# Altium schematic sheet Power_Configuration.SchDoc
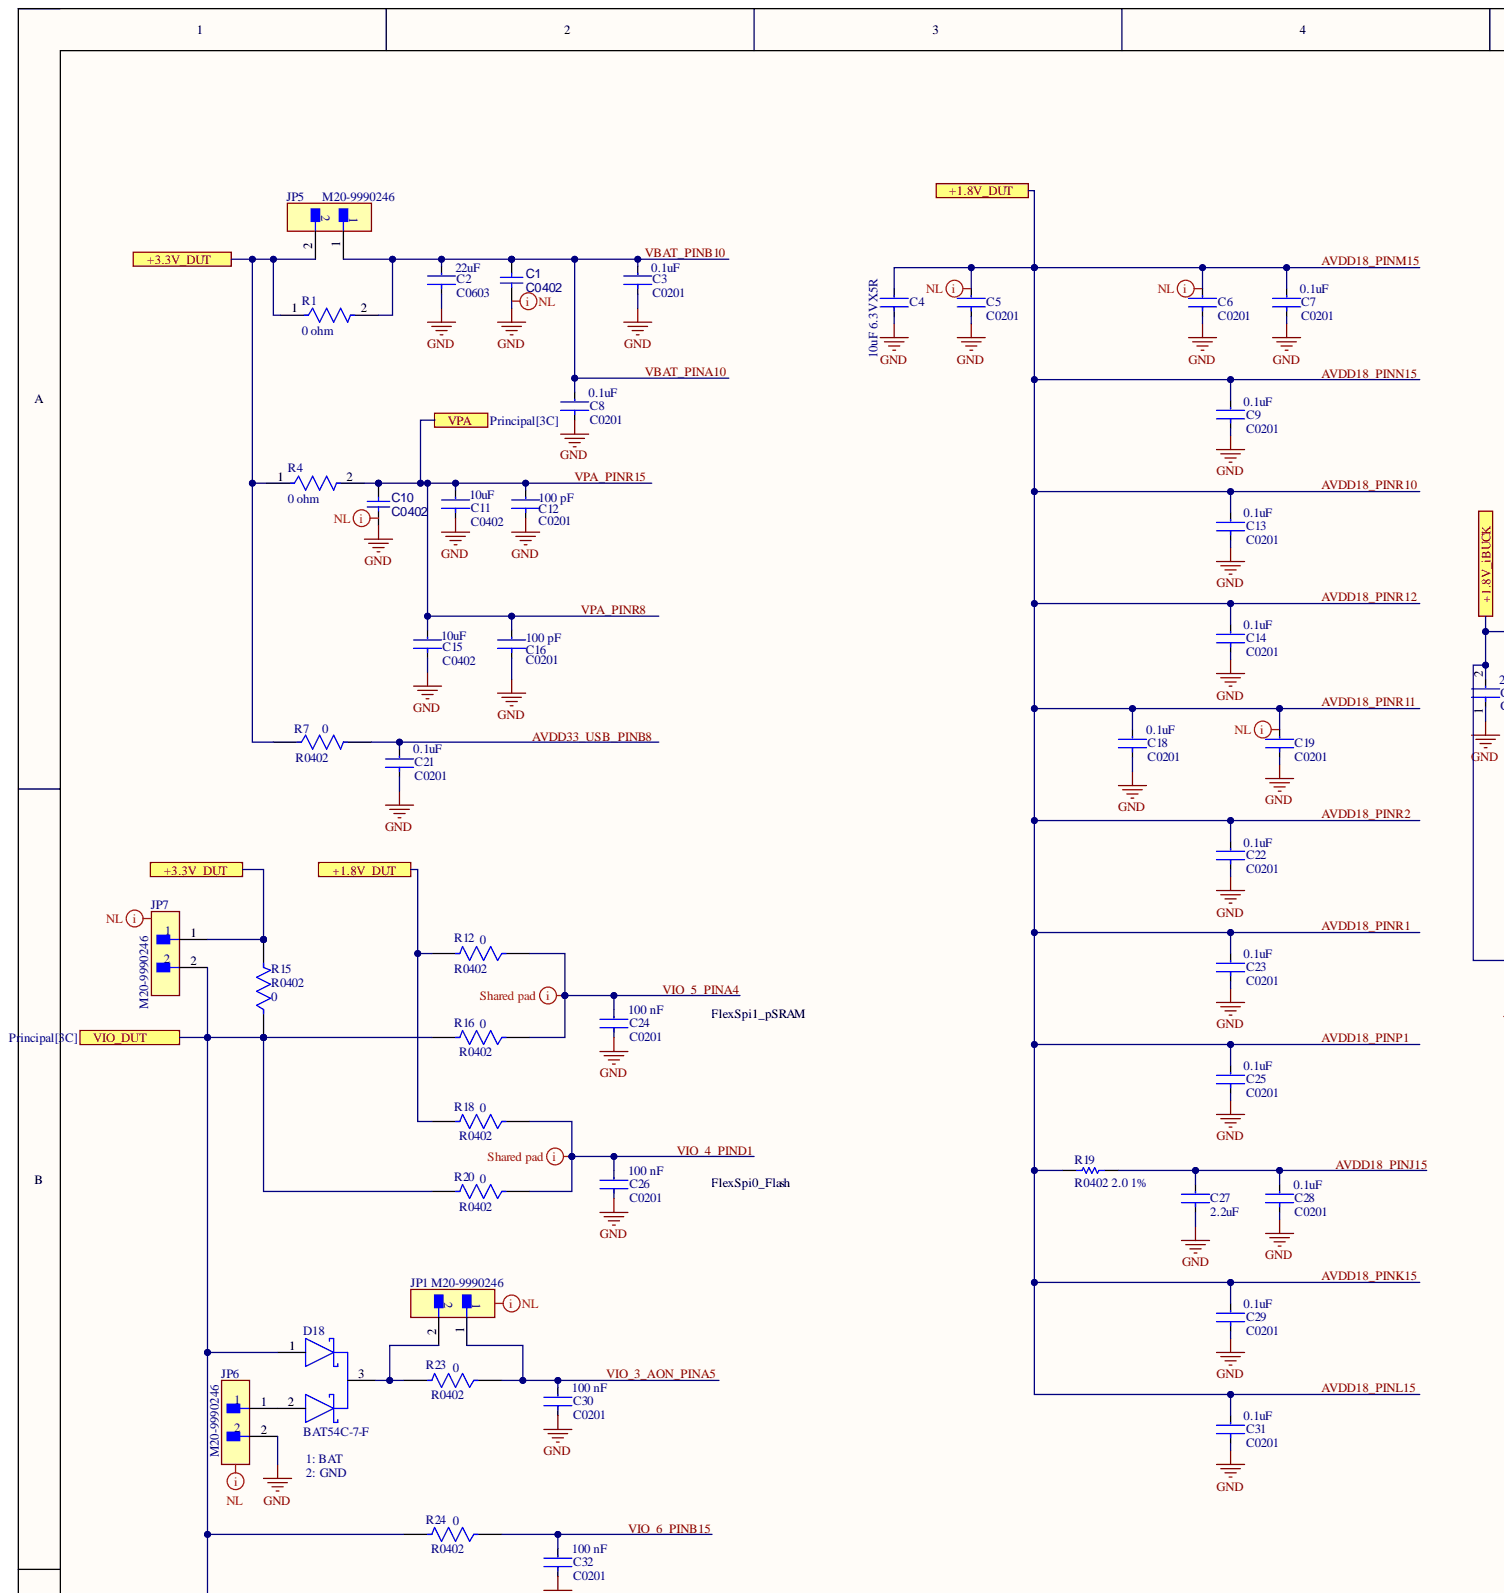
[diagram p0: Power_Configuration.SchDoc, top left]
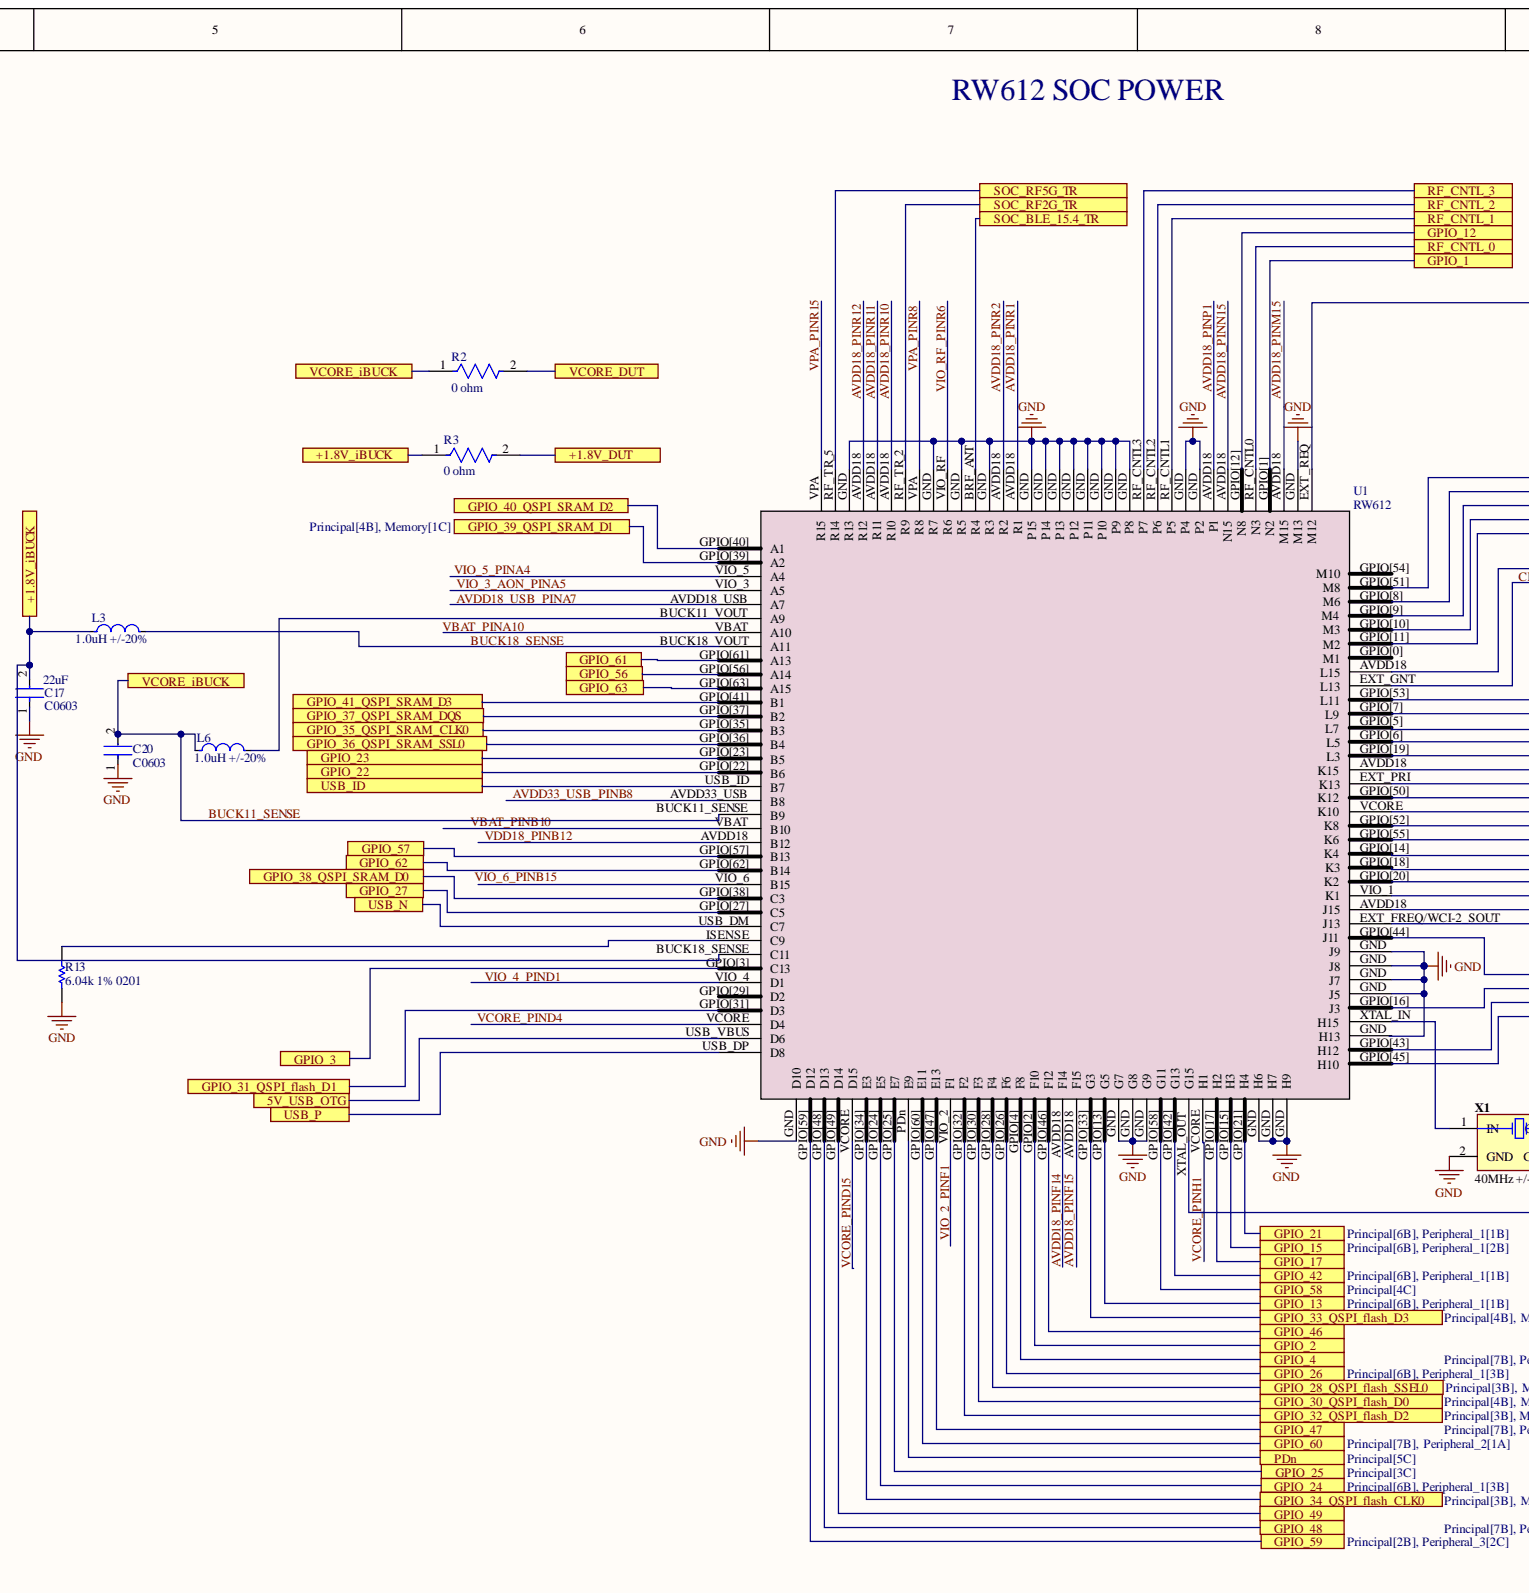
[diagram p1: Power_Configuration.SchDoc, top centre]
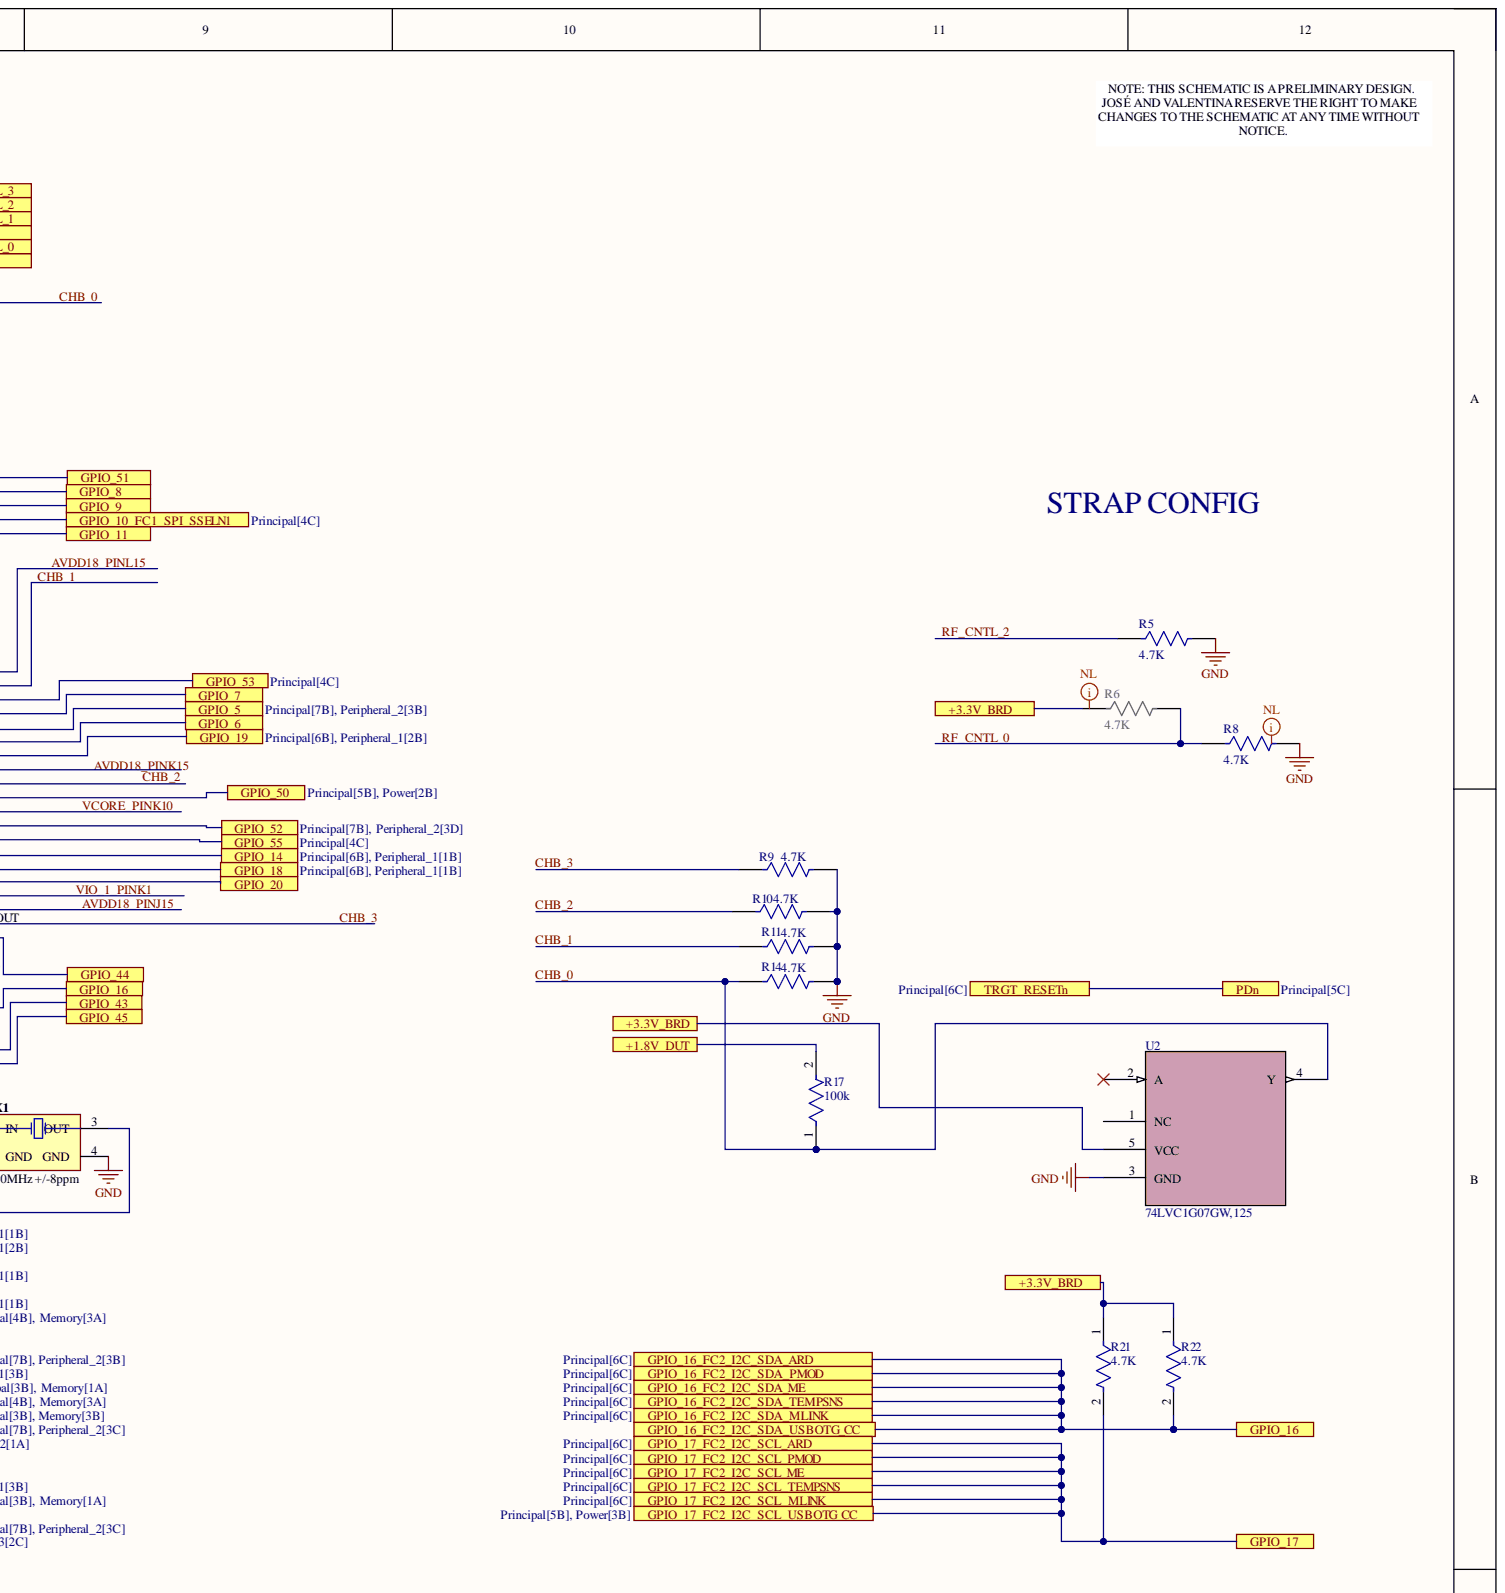
[diagram p2: Power_Configuration.SchDoc, top right]
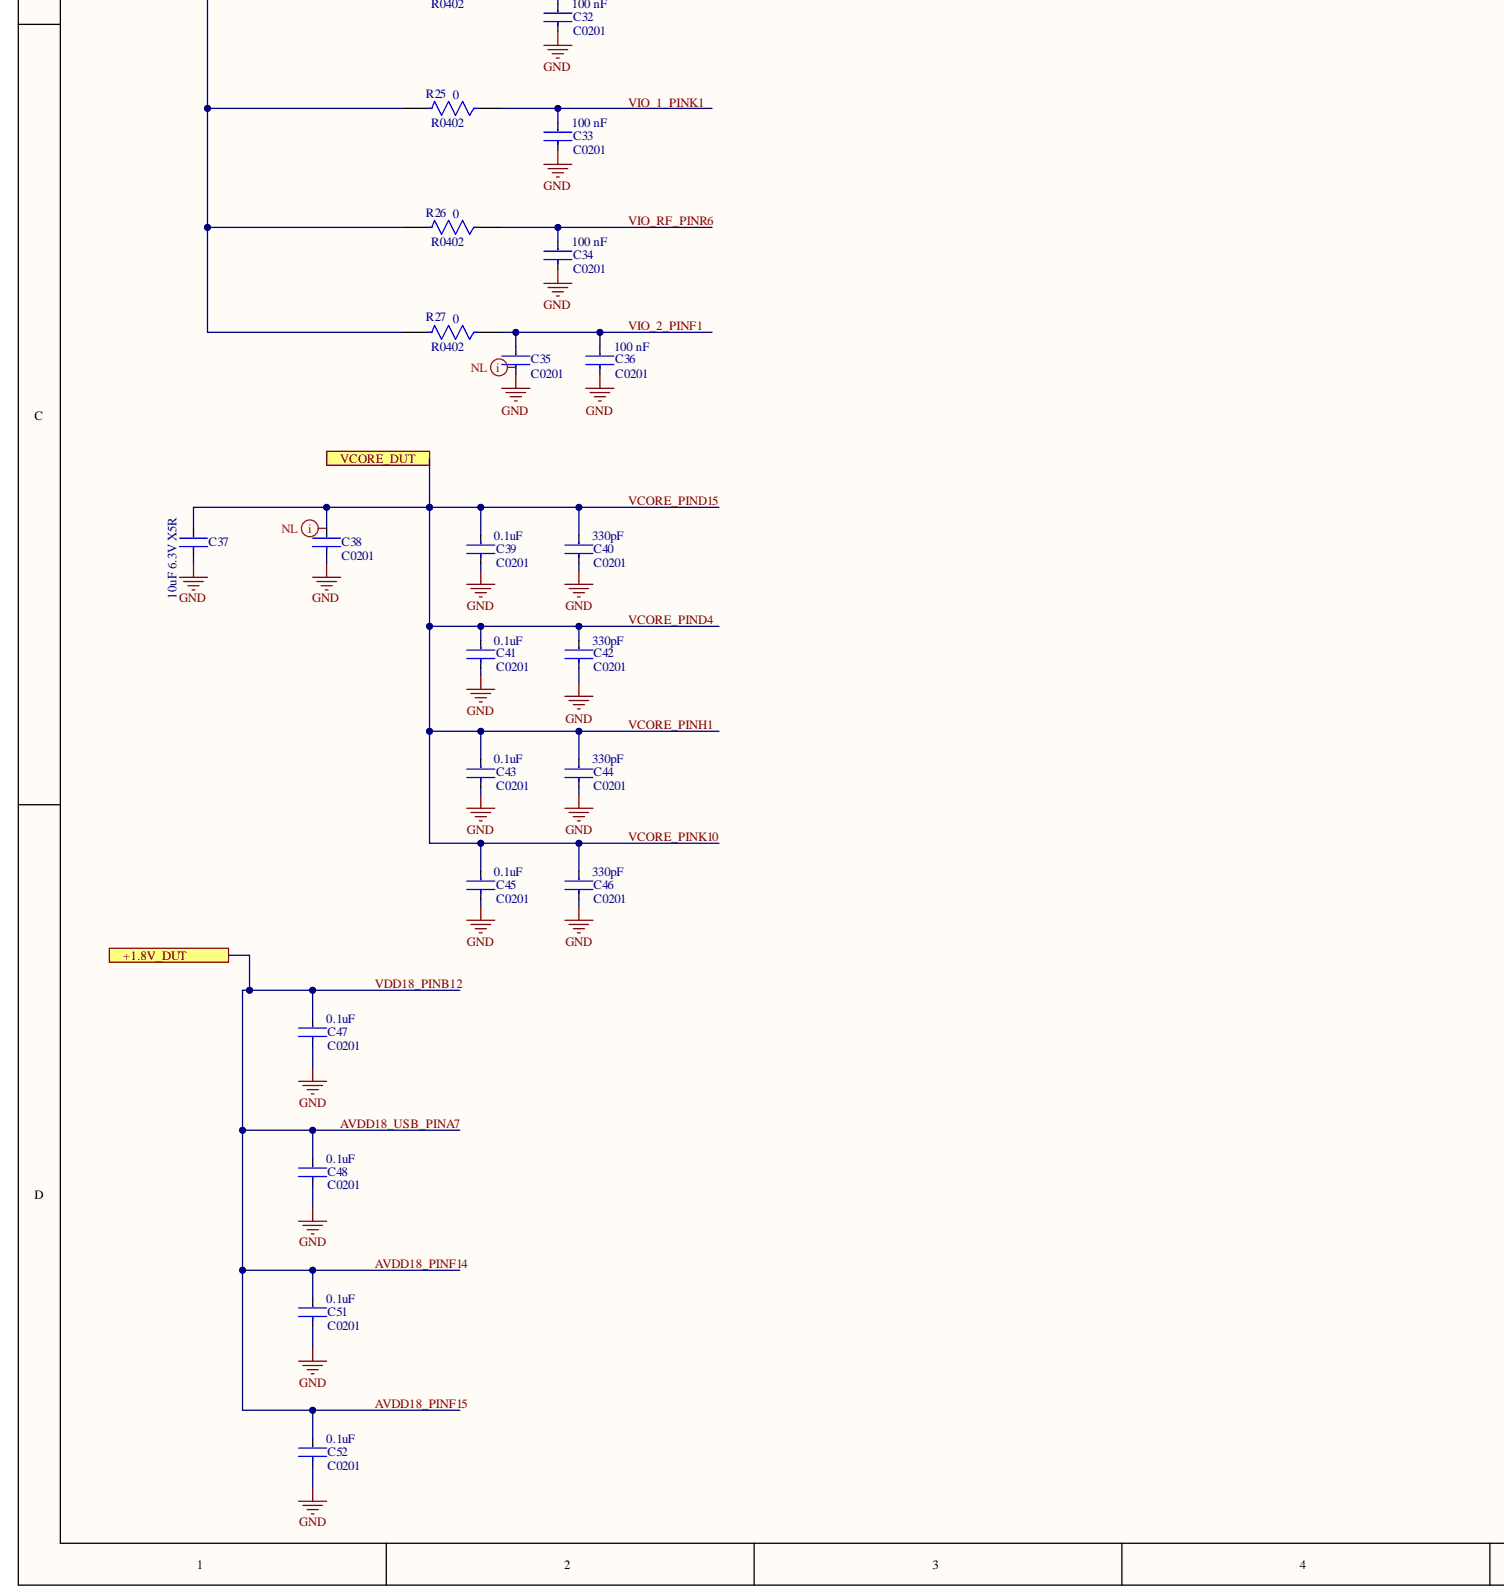
[diagram p3: Power_Configuration.SchDoc, bottom left]
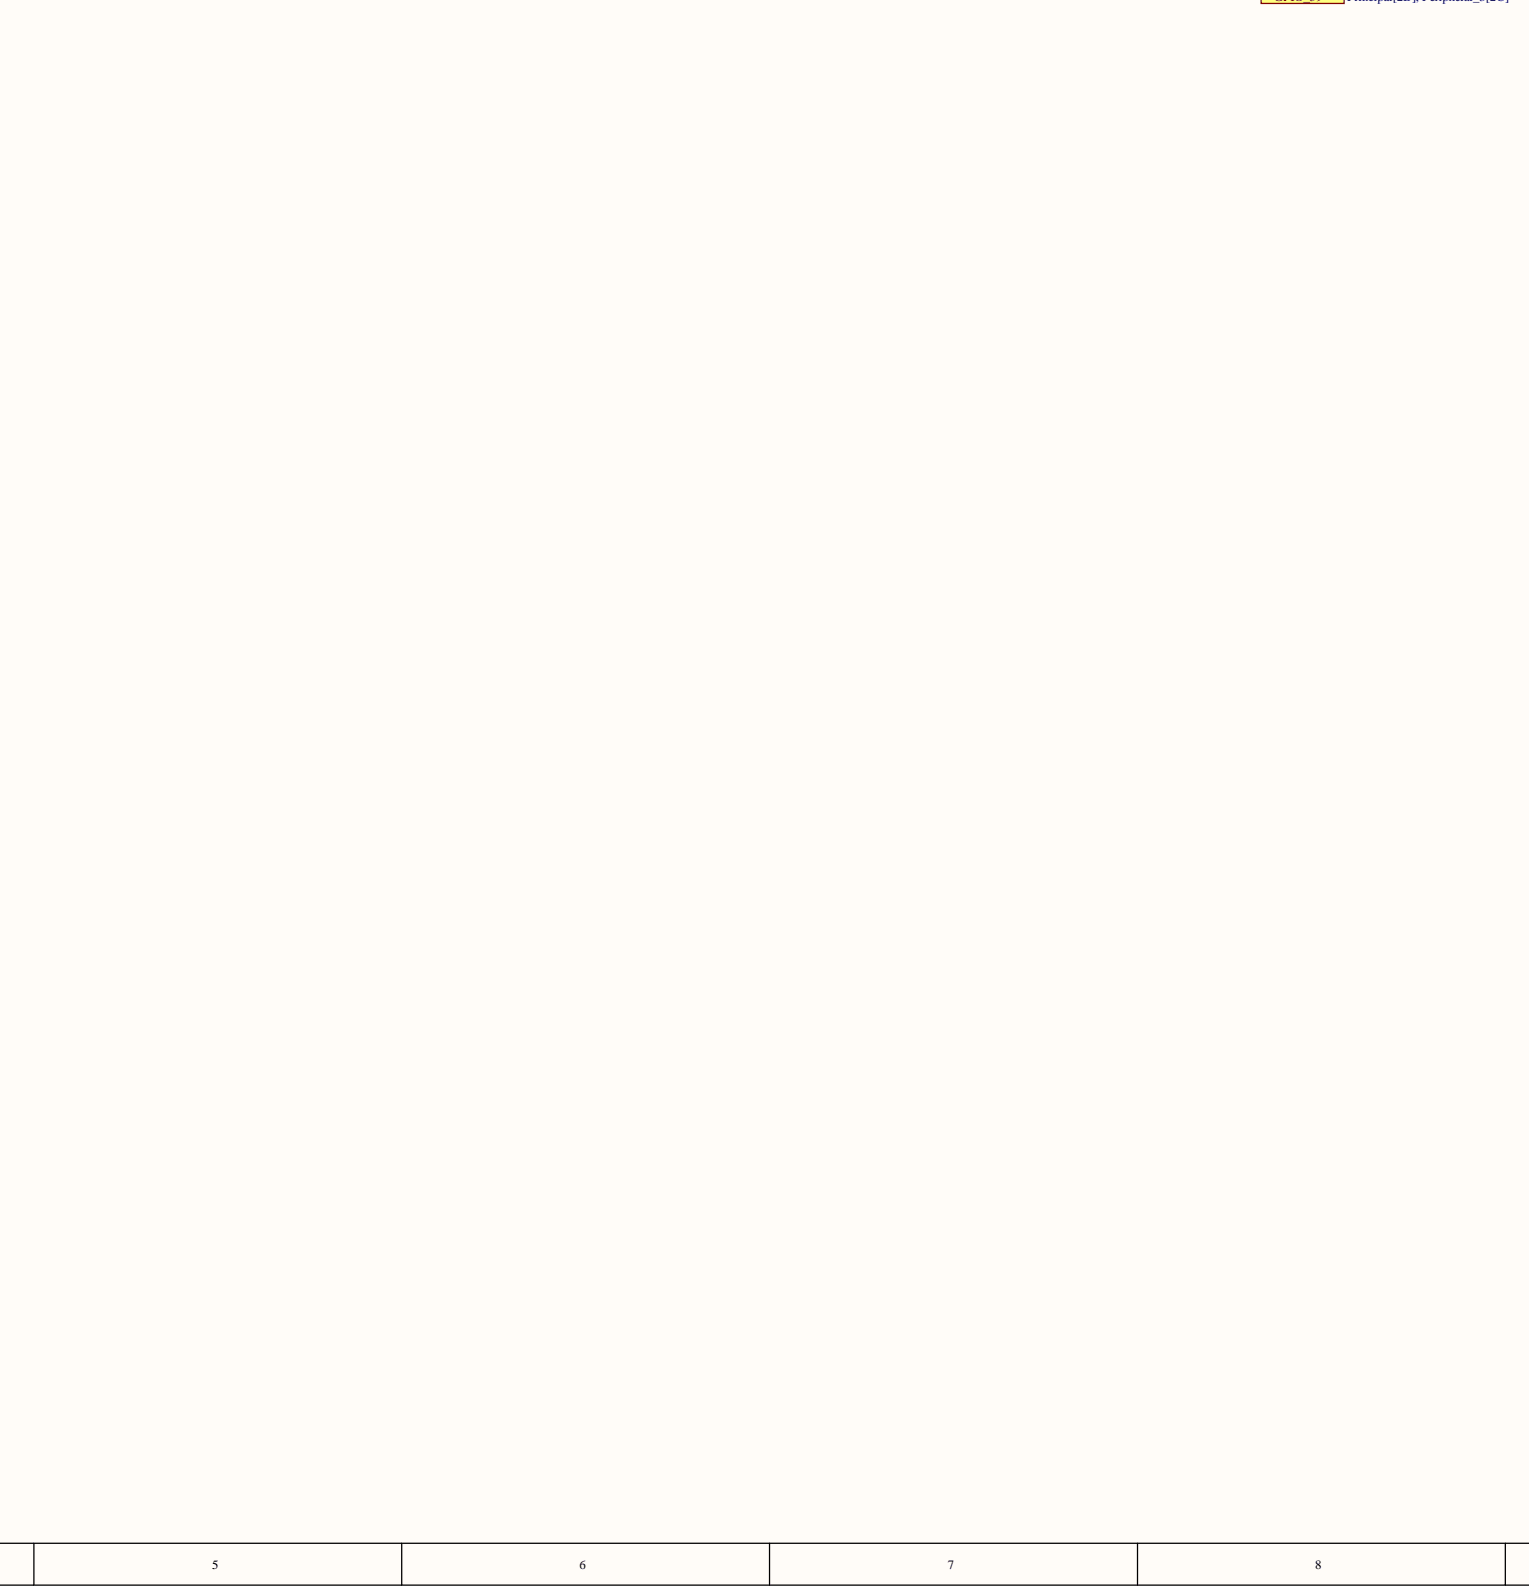
[diagram p4: Power_Configuration.SchDoc, bottom centre]
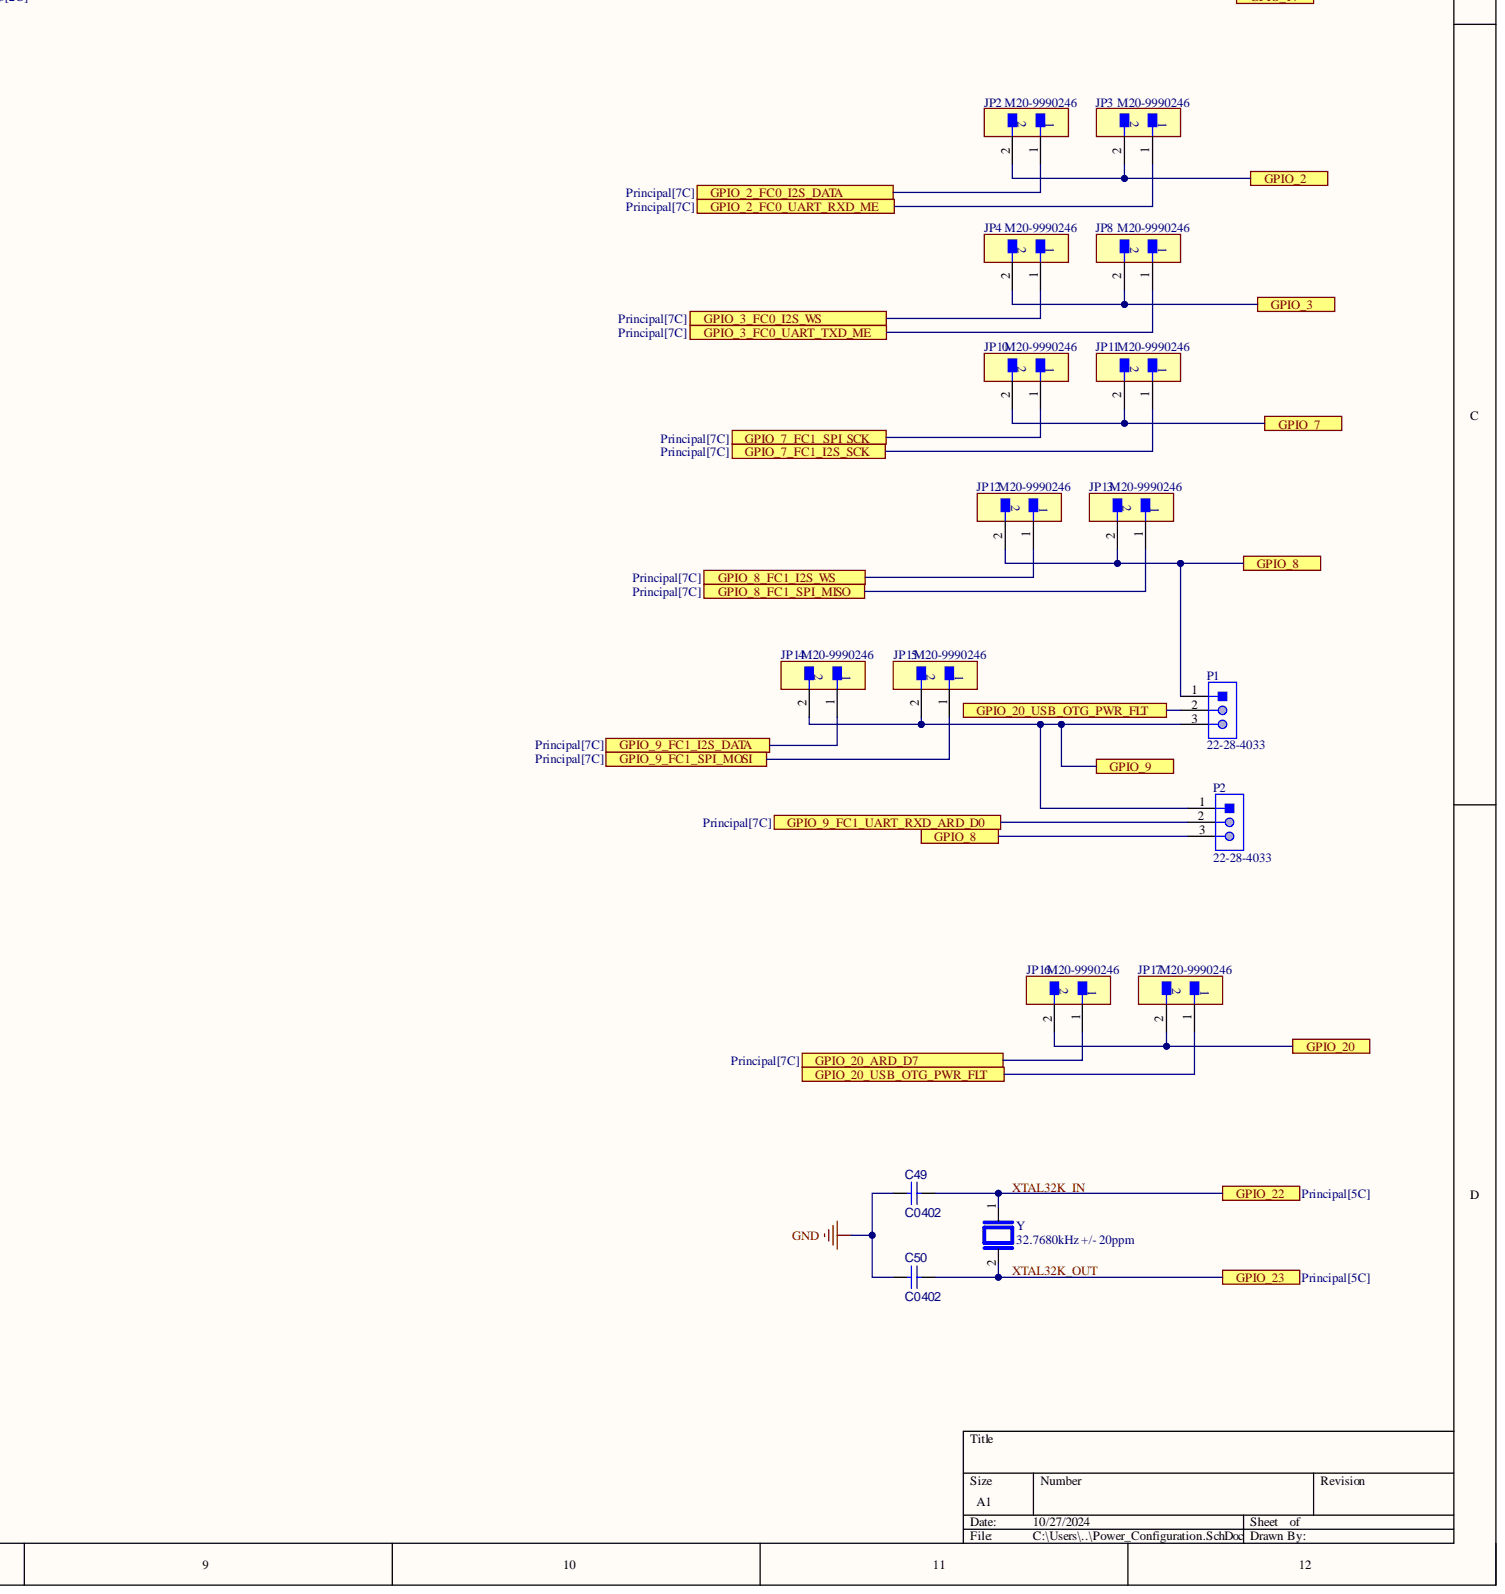
[diagram p5: Power_Configuration.SchDoc, bottom right]

## Parts
C1  C0402  [CAPC1005X56X25LL10T15]
C2  C0603  Capacitance: 22uF  [FP-CC0603-DC-MFG]
C3  C0201  value 0.1uF  [FP-CC0201-BA-MFG]
C4  10uF 6.3V X5R  Capacitance: 10uF  Dielectric: X5R  Voltage: 6.3V  [FP-GRM188-0_1-e0_2_0_55-IPC_A]
C5  C0201  [FP-CC0201-BA-MFG]
C6  C0201  [FP-CC0201-BA-MFG]
C7  C0201  value 0.1uF  [FP-CC0201-BA-MFG]
C8  C0201  value 0.1uF  [FP-CC0201-BA-MFG]
C9  C0201  value 0.1uF  [FP-CC0201-BA-MFG]
C10  C0402  [CAPC1005X56X25LL10T15]
C11  C0402  Capacitance: 10uF  [FP-C0402C106M9PACTU-MFG]
C12  C0201  value 100 pF  Capacitance: 100 pF  [FP-C0201-AB-MFG]
C13  C0201  value 0.1uF  [FP-CC0201-BA-MFG]
C14  C0201  value 0.1uF  [FP-CC0201-BA-MFG]
C15  C0402  Capacitance: 10uF  [FP-C0402C106M9PACTU-MFG]
C16  C0201  value 100 pF  Capacitance: 100 pF  [FP-C0201-AB-MFG]
C17  C0603  Capacitance: 22uF  [FP-CC0603-DC-MFG]
C18  C0201  value 0.1uF  [FP-CC0201-BA-MFG]
C19  C0201  [FP-CC0201-BA-MFG]
C20  C0603  [FP-CC0603-DC-MFG]
C21  C0201  value 0.1uF  [FP-CC0201-BA-MFG]
C22  C0201  value 0.1uF  [FP-CC0201-BA-MFG]
C23  C0201  value 0.1uF  [FP-CC0201-BA-MFG]
C24  C0201  value 100 nF  Capacitance: 100 nF  [FP-CC0201-BA-MFG]
C25  C0201  value 0.1uF  [FP-CC0201-BA-MFG]
C26  C0201  value 100 nF  Capacitance: 100 nF  [FP-CC0201-BA-MFG]
C27  2.2uF  [FP-CC0201-BC-MFG]
C28  C0201  value 0.1uF  [FP-CC0201-BA-MFG]
C29  C0201  value 0.1uF  [FP-CC0201-BA-MFG]
C30  C0201  value 100 nF  Capacitance: 100 nF  [FP-CC0201-BA-MFG]
C31  C0201  value 0.1uF  [FP-CC0201-BA-MFG]
C32  C0201  value 100 nF  Capacitance: 100 nF  [FP-CC0201-BA-MFG]
C33  C0201  value 100 nF  Capacitance: 100 nF  [FP-CC0201-BA-MFG]
C34  C0201  value 100 nF  Capacitance: 100 nF  [FP-CC0201-BA-MFG]
C35  C0201  [FP-CC0201-BA-MFG]
C36  C0201  value 100 nF  Capacitance: 100 nF  [FP-CC0201-BA-MFG]
C37  10uF 6.3V X5R  Capacitance: 10uF  Dielectric: X5R  Voltage: 6.3V  [FP-GRM188-0_1-e0_2_0_55-IPC_A]
C38  C0201  [FP-CC0201-BA-MFG]
C39  C0201  value 0.1uF  [FP-CC0201-BA-MFG]
C40  C0201  value 330pF  [FP-CC0201-BA-MFG]
C41  C0201  value 0.1uF  [FP-CC0201-BA-MFG]
C42  C0201  value 330pF  [FP-CC0201-BA-MFG]
C43  C0201  value 0.1uF  [FP-CC0201-BA-MFG]
C44  C0201  value 330pF  [FP-CC0201-BA-MFG]
C45  C0201  value 0.1uF  [FP-CC0201-BA-MFG]
C46  C0201  value 330pF  [FP-CC0201-BA-MFG]
C47  C0201  value 0.1uF  [FP-CC0201-BA-MFG]
C48  C0201  value 0.1uF  [FP-CC0201-BA-MFG]
C49  C0402  [CAPC1005X56X25NL10T15]
C50  C0402  [CAPC1005X56X25NL10T15]
C51  C0201  value 0.1uF  [FP-CC0201-BA-MFG]
C52  C0201  value 0.1uF  [FP-CC0201-BA-MFG]
D18  BAT54C-7-F  [FP-SOT23-IPC_B]
JP1  M20-9990246  [FP-M20-9990246-MFG]
JP2  M20-9990246  [FP-M20-9990246-MFG]
JP3  M20-9990246  [FP-M20-9990246-MFG]
JP4  M20-9990246  [FP-M20-9990246-MFG]
JP5  M20-9990246  [FP-M20-9990246-MFG]
JP6  M20-9990246  [FP-M20-9990246-MFG]
JP7  M20-9990246  [FP-M20-9990246-MFG]
JP8  M20-9990246  [FP-M20-9990246-MFG]
JP10  M20-9990246  [FP-M20-9990246-MFG]
JP11  M20-9990246  [FP-M20-9990246-MFG]
JP12  M20-9990246  [FP-M20-9990246-MFG]
JP13  M20-9990246  [FP-M20-9990246-MFG]
JP14  M20-9990246  [FP-M20-9990246-MFG]
JP15  M20-9990246  [FP-M20-9990246-MFG]
JP16  M20-9990246  [FP-M20-9990246-MFG]
JP17  M20-9990246  [FP-M20-9990246-MFG]
L3  1.0uH +/-20%  [FP-VCHA042A-MFG]
L6  1.0uH +/-20%  [FP-VCHA042A-MFG]
P1  22-28-4033  [MOLX-22-28-4033_V]
P2  22-28-4033  [MOLX-22-28-4033_V]
R1  0 ohm  [FP-CR0805-IPC_C]
R2  0 ohm  [FP-CR0805-IPC_C]
R3  0 ohm  [FP-CR0805-IPC_C]
R4  0 ohm  [FP-CR0805-IPC_C]
R5  4.7K  [FP-CR0402-IPC_C]
R6  4.7K  [FP-CR0402-IPC_C]
R7  R0402  [FP-CR0402-IPC_B]
R8  4.7K  [FP-CR0402-IPC_C]
R9  4.7K  [FP-CR0402-IPC_C]
R10  4.7K  [FP-CR0402-IPC_C]
R11  4.7K  [FP-CR0402-IPC_C]  (value not drawn)
R12  R0402  [FP-CR0402-IPC_B]
R13  6.04k 1% 0201  Case-EIA: 0201  [RESC0201(0603)_N]
R14  4.7K  [FP-CR0402-IPC_C]  (value not drawn)
R15  R0402  [FP-CR0402-IPC_B]
R16  R0402  [FP-CR0402-IPC_B]
R17  100k  [FP-CR0402-IPC_C]
R18  R0402  [FP-CR0402-IPC_B]
R19  R0402 2.0 1%  [RESC1005X40X25ML10T10]
R20  R0402  [FP-CR0402-IPC_B]
R21  4.7K  [FP-CR0402-IPC_C]
R22  4.7K  [FP-CR0402-IPC_C]
R23  R0402  [FP-CR0402-IPC_B]
R24  R0402  [FP-CR0402-IPC_B]
R25  R0402  [FP-CR0402-IPC_B]
R26  R0402  [FP-CR0402-IPC_B]
R27  R0402  [FP-CR0402-IPC_B]
U1  RW612  [TFBGA145C50P15X15]
U2  74LVC1G07GW,125  [TSSOP5_SOT353-1_NEX]
X1  40MHz +/-8ppm  Frequency: 40MHz  [ABRA-ABM3B-4_V]
Y  32.7680kHz +/- 20ppm  [XTAL_CM7V-T1A_32.768KHZ_12.5PF_+/-20PPM_TA_QC]

## Ports
5V_USB_OTG, +1.8V_DUT, +1.8V_iBUCK, +3.3V_BRD, +3.3V_DUT, GPIO_1, GPIO_2, GPIO_2_FC0_I2S_DATA, GPIO_2_FC0_UART_RXD_ME, GPIO_3, GPIO_3_FC0_I2S_WS, GPIO_3_FC0_UART_TXD_ME, GPIO_4, GPIO_5, GPIO_6, GPIO_7, GPIO_7_FC1_I2S_SCK, GPIO_7_FC1_SPI_SCK, GPIO_8, GPIO_8_FC1_I2S_WS, GPIO_8_FC1_SPI_MISO, GPIO_9, GPIO_9_FC1_I2S_DATA, GPIO_9_FC1_SPI_MOSI, GPIO_9_FC1_UART_RXD_ARD_D0, GPIO_10_FC1_SPI_SSELN1, GPIO_11, GPIO_12, GPIO_13, GPIO_14, GPIO_15, GPIO_16, GPIO_16_FC2_I2C_SDA_ARD, GPIO_16_FC2_I2C_SDA_ME, GPIO_16_FC2_I2C_SDA_MLINK, GPIO_16_FC2_I2C_SDA_PMOD, GPIO_16_FC2_I2C_SDA_TEMPSNS, GPIO_16_FC2_I2C_SDA_USBOTG_CC, GPIO_17, GPIO_17_FC2_I2C_SCL_ARD, GPIO_17_FC2_I2C_SCL_ME, GPIO_17_FC2_I2C_SCL_MLINK, GPIO_17_FC2_I2C_SCL_PMOD, GPIO_17_FC2_I2C_SCL_TEMPSNS, GPIO_17_FC2_I2C_SCL_USBOTG_CC, GPIO_18, GPIO_19, GPIO_20, GPIO_20_ARD_D7, GPIO_20_USB_OTG_PWR_FLT, GPIO_21, GPIO_22, GPIO_23, GPIO_24, GPIO_25, GPIO_26, GPIO_27, GPIO_28_QSPI_flash_SSEL0, GPIO_30_QSPI_flash_D0, GPIO_31_QSPI_flash_D1, GPIO_32_QSPI_flash_D2, GPIO_33_QSPI_flash_D3, GPIO_34_QSPI_flash_CLK0, GPIO_35_QSPI_SRAM_CLK0, GPIO_36_QSPI_SRAM_SSL0, GPIO_37_QSPI_SRAM_DQS, GPIO_38_QSPI_SRAM_D0, GPIO_39_QSPI_SRAM_D1, GPIO_40_QSPI_SRAM_D2, GPIO_41_QSPI_SRAM_D3, GPIO_42, GPIO_43, GPIO_44, GPIO_45, GPIO_46, GPIO_47, GPIO_48, GPIO_49, GPIO_50, GPIO_51, GPIO_52, GPIO_53, GPIO_55, GPIO_56, GPIO_57, GPIO_58, GPIO_59, GPIO_60, GPIO_61, GPIO_62, GPIO_63, PDn, RF_CNTL_0, RF_CNTL_1, RF_CNTL_2, RF_CNTL_3, SOC_BLE_15.4_TR, SOC_RF2G_TR, SOC_RF5G_TR, TRGT_RESETn, USB_ID, USB_N, USB_P, VCORE_DUT, VCORE_iBUCK, VIO_DUT, VPA

## Nets
GND: C1.2 C2.1 C3.1 C4.1 C5.1 C6.1 C7.1 C8.1 C9.1 C10.2 C11.1 C12.1 C13.1 C14.1 C15.1 C16.1 C17.1 C18.1 C19.1 C20.1 C21.1 C22.1 C23.1 C24.1 C25.1 C26.1 C27.1 C28.1 C29.1 C30.1 C31.1 C32.1 C33.1 C34.1 C35.1 C36.1 C37.1 C38.1 C39.1 C40.1 C41.1 C42.1 C43.1 C44.1 C45.1 C46.1 C47.1 C48.1 C49.1 C50.1 C51.1 C52.1 JP6.2 R5.2 R8.2 R9.2 R10.2 R11.2 R13.1 R14.2 U1.GND U2.3(GND) X1.2(GND) X1.4(GND)
5V_USB_OTG: U1.USB_VBUS(D6) ; port 5V_USB_OTG
+1.8V_iBUCK: C17.2 L3.1 R3.1 U1.BUCK18_SENSE(C11) ; port +1.8V_iBUCK
+3.3V_BRD: R6.1 R21.1 R22.1 U2.5(VCC) ; port +3.3V_BRD
+3.3V_DUT: JP5.2 JP7.1 R1.1 R4.1 R7.1 R15.2 ; port +3.3V_DUT
AVDD18_PINF14: C4.2 C5.2 C6.2 C7.2 C9.2 C13.2 C14.2 C18.2 C19.2 C22.2 C23.2 C25.2 C29.2 C31.2 C47.2 C48.2 C51.2 C52.2 R3.2 R12.2 R17.2 R18.2 R19.1 U1.AVDD18 U1.AVDD18_USB(A7) ; port +1.8V_DUT
AVDD18_PINJ15: C27.2 C28.2 R19.2
AVDD33_USB_PINB8: C21.2 R7.2 U1.AVDD33_USB(B8)
BUCK11_SENSE: C20.2 L6.1 R2.1 U1.BUCK11_SENSE(B9) ; port VCORE_iBUCK
BUCK18_SENSE: L3.2 U1.BUCK18_VOUT(A11)
CHB_0: R14.1 R17.1 U1.EXT_REQ(M12) U2.4(Y)
CHB_1: R11.1 U1.EXT_GNT(L13)
CHB_2: R10.1 U1.EXT_PRI(K13)
CHB_3: R9.1 U1.EXT_FREQ/WCI-2_SOUT(J13)
GPIO_1: U1.GPIO[1](N2) ; port GPIO_1
GPIO_2: JP2.2 JP3.2 U1.GPIO[2](F10) ; port GPIO_2
GPIO_2_FC0_I2S_DATA: JP2.1 ; port GPIO_2_FC0_I2S_DATA
GPIO_2_FC0_UART_RXD_ME: JP3.1 ; port GPIO_2_FC0_UART_RXD_ME
GPIO_3: JP4.2 JP8.2 U1.GPIO[3](C13) ; port GPIO_3
GPIO_3_FC0_I2S_WS: JP4.1 ; port GPIO_3_FC0_I2S_WS
GPIO_3_FC0_UART_TXD_ME: JP8.1 ; port GPIO_3_FC0_UART_TXD_ME
GPIO_4: U1.GPIO[4](F8) ; port GPIO_4
GPIO_5: U1.GPIO[5](L7) ; port GPIO_5
GPIO_6: U1.GPIO[6](L5) ; port GPIO_6
GPIO_7: JP10.2 JP11.2 U1.GPIO[7](L9) ; port GPIO_7
GPIO_7_FC1_I2S_SCK: JP11.1 ; port GPIO_7_FC1_I2S_SCK
GPIO_7_FC1_SPI_SCK: JP10.1 ; port GPIO_7_FC1_SPI_SCK
GPIO_8: JP12.2 JP13.2 P1.1 P2.3 U1.GPIO[8](M6) ; port GPIO_8
GPIO_8_FC1_I2S_WS: JP12.1 ; port GPIO_8_FC1_I2S_WS
GPIO_8_FC1_SPI_MISO: JP13.1 ; port GPIO_8_FC1_SPI_MISO
GPIO_9: JP14.2 JP15.2 P1.3 P2.1 U1.GPIO[9](M4) ; port GPIO_9
GPIO_9_FC1_I2S_DATA: JP14.1 ; port GPIO_9_FC1_I2S_DATA
GPIO_9_FC1_SPI_MOSI: JP15.1 ; port GPIO_9_FC1_SPI_MOSI
GPIO_9_FC1_UART_RXD_ARD_D0: P2.2 ; port GPIO_9_FC1_UART_RXD_ARD_D0
GPIO_10_FC1_SPI_SSELN1: U1.GPIO[10](M3) ; port GPIO_10_FC1_SPI_SSELN1
GPIO_11: U1.GPIO[11](M2) ; port GPIO_11
GPIO_12: U1.GPIO[12](N8) ; port GPIO_12
GPIO_13: U1.GPIO[13] ; port GPIO_13
GPIO_14: U1.GPIO[14](K4) ; port GPIO_14
GPIO_15: U1.GPIO[15](H3) ; port GPIO_15
GPIO_16: R22.2 U1.GPIO[16](J3) ; port GPIO_16, port GPIO_16_FC2_I2C_SDA_ARD, port GPIO_16_FC2_I2C_SDA_ME, port GPIO_16_FC2_I2C_SDA_MLINK, port GPIO_16_FC2_I2C_SDA_PMOD, port GPIO_16_FC2_I2C_SDA_TEMPSNS, port GPIO_16_FC2_I2C_SDA_USBOTG_CC
GPIO_17: R21.2 U1.GPIO[17](H2) ; port GPIO_17, port GPIO_17_FC2_I2C_SCL_ARD, port GPIO_17_FC2_I2C_SCL_ME, port GPIO_17_FC2_I2C_SCL_MLINK, port GPIO_17_FC2_I2C_SCL_PMOD, port GPIO_17_FC2_I2C_SCL_TEMPSNS, port GPIO_17_FC2_I2C_SCL_USBOTG_CC
GPIO_18: U1.GPIO[18](K3) ; port GPIO_18
GPIO_19: U1.GPIO[19](L3) ; port GPIO_19
GPIO_20: JP16.2 JP17.2 U1.GPIO[20](K2) ; port GPIO_20
GPIO_20_ARD_D7: JP16.1 ; port GPIO_20_ARD_D7
GPIO_20_USB_OTG_PWR_FLT: JP17.1 P1.2 ; port GPIO_20_USB_OTG_PWR_FLT
GPIO_21: U1.GPIO[21](H4) ; port GPIO_21
GPIO_24: U1.GPIO[24] ; port GPIO_24
GPIO_25: U1.GPIO[25](E7) ; port GPIO_25
GPIO_26: U1.GPIO[26](F6) ; port GPIO_26
GPIO_27: U1.GPIO[27](C5) ; port GPIO_27
GPIO_28_QSPI_flash_SSEL0: U1.GPIO[28](F4) ; port GPIO_28_QSPI_flash_SSEL0
GPIO_30_QSPI_flash_D0: U1.GPIO[30](F3) ; port GPIO_30_QSPI_flash_D0
GPIO_31_QSPI_flash_D1: U1.GPIO[31](D3) ; port GPIO_31_QSPI_flash_D1
GPIO_32_QSPI_flash_D2: U1.GPIO[32](F2) ; port GPIO_32_QSPI_flash_D2
GPIO_33_QSPI_flash_D3: U1.GPIO[33](G3) ; port GPIO_33_QSPI_flash_D3
GPIO_34_QSPI_flash_CLK0: U1.GPIO[34](E3) ; port GPIO_34_QSPI_flash_CLK0
GPIO_35_QSPI_SRAM_CLK0: U1.GPIO[35](B3) ; port GPIO_35_QSPI_SRAM_CLK0
GPIO_36_QSPI_SRAM_SSL0: U1.GPIO[36](B4) ; port GPIO_36_QSPI_SRAM_SSL0
GPIO_37_QSPI_SRAM_DQS: U1.GPIO[37](B2) ; port GPIO_37_QSPI_SRAM_DQS
GPIO_38_QSPI_SRAM_D0: U1.GPIO[38](C3) ; port GPIO_38_QSPI_SRAM_D0
GPIO_39_QSPI_SRAM_D1: U1.GPIO[39](A2) ; port GPIO_39_QSPI_SRAM_D1
GPIO_40_QSPI_SRAM_D2: U1.GPIO[40](A1) ; port GPIO_40_QSPI_SRAM_D2
GPIO_41_QSPI_SRAM_D3: U1.GPIO[41](B1) ; port GPIO_41_QSPI_SRAM_D3
GPIO_42: U1.GPIO[42](G13) ; port GPIO_42
GPIO_43: U1.GPIO[43](H12) ; port GPIO_43
GPIO_44: U1.GPIO[44](J11) ; port GPIO_44
GPIO_45: U1.GPIO[45](H10) ; port GPIO_45
GPIO_46: U1.GPIO[46](F12) ; port GPIO_46
GPIO_47: U1.GPIO[47](E13) ; port GPIO_47
GPIO_48: U1.GPIO[48](D13) ; port GPIO_48
GPIO_49: U1.GPIO[49](D14) ; port GPIO_49
GPIO_50: U1.GPIO[50](K12) ; port GPIO_50
GPIO_51: U1.GPIO[51](M8) ; port GPIO_51
GPIO_52: U1.GPIO[52](K8) ; port GPIO_52
GPIO_53: U1.GPIO[53](L11) ; port GPIO_53
GPIO_55: U1.GPIO[55](K6) ; port GPIO_55
GPIO_56: U1.GPIO[56](A14) ; port GPIO_56
GPIO_57: U1.GPIO[57](B13) ; port GPIO_57
GPIO_58: U1.GPIO[58](G11) ; port GPIO_58
GPIO_59: U1.GPIO[59](D12) ; port GPIO_59
GPIO_60: U1.GPIO[60](E11) ; port GPIO_60
GPIO_61: U1.GPIO[61](A13) ; port GPIO_61
GPIO_62: U1.GPIO[62](B14) ; port GPIO_62
GPIO_63: U1.GPIO[63](A15) ; port GPIO_63
PDn: U1.PDn(E9) ; port PDn, port TRGT_RESETn
RF_CNTL_0: R6.2 R8.1
RF_CNTL_1: U1.RF_CNTL1(P5) ; port RF_CNTL_1
RF_CNTL_2: R5.1
RF_CNTL_3: U1.RF_CNTL3(P7) ; port RF_CNTL_3
SOC_BLE_15.4_TR: U1.BRF_ANT(R4) ; port SOC_BLE_15.4_TR
SOC_RF2G_TR: U1.RF_TR_2(R9) ; port SOC_RF2G_TR
SOC_RF5G_TR: U1.RF_TR_5(R14) ; port SOC_RF5G_TR
USB_ID: U1.USB_ID(B7) ; port USB_ID
USB_N: U1.USB_DM(C7) ; port USB_N
USB_P: U1.USB_DP(D8) ; port USB_P
VBAT_PINA10: C1.1 C2.2 C3.2 C8.2 JP5.1 R1.2 U1.VBAT(B10)
VCORE_PIND4: C37.2 C38.2 C39.2 C40.2 C41.2 C42.2 C43.2 C44.2 C45.2 C46.2 R2.2 U1.VCORE ; port VCORE_DUT
VIO_1_PINK1: C33.2 R25.1 U1.VIO_1(K1)
VIO_2_PINF1: C35.2 C36.2 R27.1
VIO_3_AON_PINA5: C30.2 JP1.1 R23.1 U1.VIO_3(A5)
VIO_4_PIND1: C26.2 R18.1 R20.1 U1.VIO_4(D1)
VIO_5_PINA4: C24.2 R12.1 R16.1 U1.VIO_5(A4)
VIO_6_PINB15: C32.2 R24.1
VIO_DUT: D18.1 JP7.2 R15.1 R16.2 R20.2 R24.2 R25.2 R26.2 R27.2 ; port VIO_DUT
VIO_RF_PINR6: C34.2 R26.1 U1.VIO_RF(R6)
VPA_PINR8: C10.1 C11.2 C12.2 C15.2 C16.2 R4.2 U1.VPA(R15) ; port VPA
XTAL32K_IN: C49.2 U1.GPIO[22](B6) Y.1 ; port GPIO_22
XTAL32K_OUT: C50.2 U1.GPIO[23](B5) Y.2 ; port GPIO_23
NetD18_2: D18.2 JP6.1
NetD18_3: D18.3 JP1.2 R23.2
NetL6_2: L6.2 U1.BUCK11_VOUT(A9)
NetR13_2: R13.2 U1.ISENSE(C9)
NetU1_AVDD18: U1.AVDD18
NetU1_GND: U1.GND
NetU1_GPIO[0]: U1.GPIO[0](M1)
NetU1_GPIO[29]: U1.GPIO[29](D2)
NetU1_GPIO[54]: U1.GPIO[54](M10)
NetU1_RF_CNTL0: U1.RF_CNTL0(N3) ; port RF_CNTL_0
NetU1_RF_CNTL2: U1.RF_CNTL2(P6) ; port RF_CNTL_2
NetU1_VCORE: U1.VCORE
NetU1_VIO_2: U1.VIO_2(F1)
NetU1_VIO_6: U1.VIO_6(B15)
NetU1_XTAL_IN: U1.XTAL_IN(H15) X1.1(IN)
NetU1_XTAL_OUT: U1.XTAL_OUT(G15) X1.3(OUT)
NetU2_1: U2.1(NC)
NetU2_2: U2.2(A)
